# Altium schematic sheet LED v0.1.SchDoc
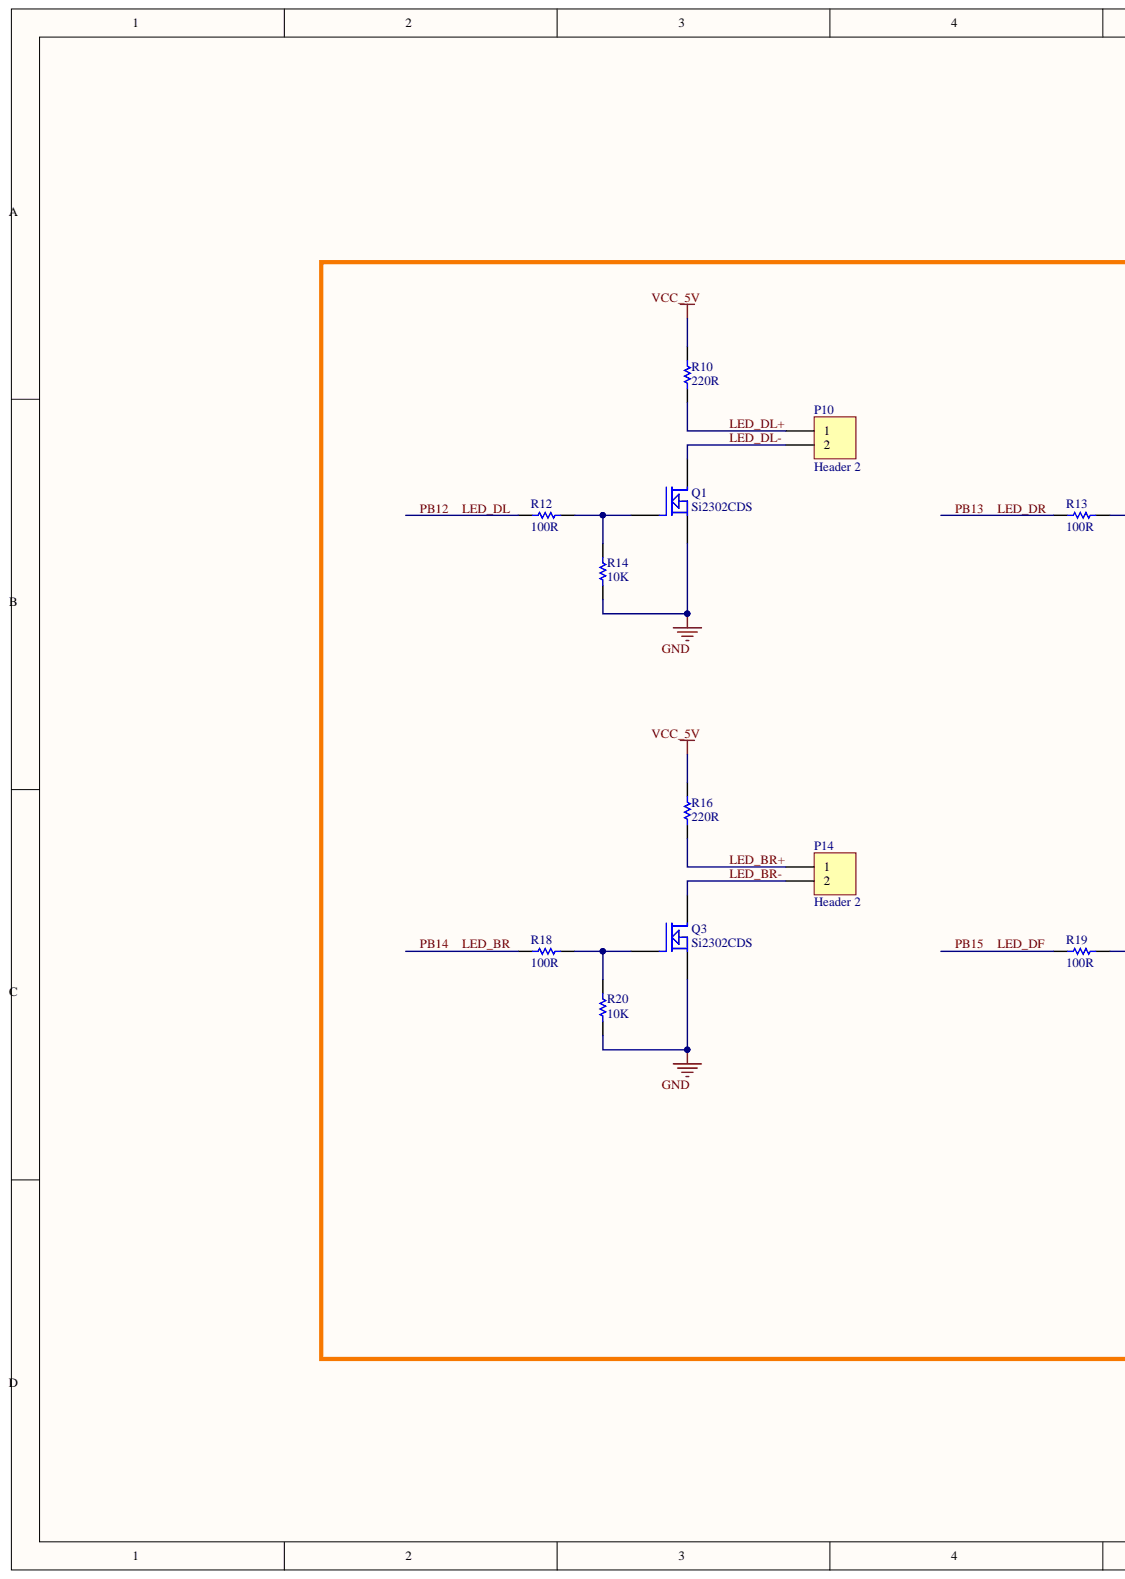
[diagram p0: LED v0.1.SchDoc, left]
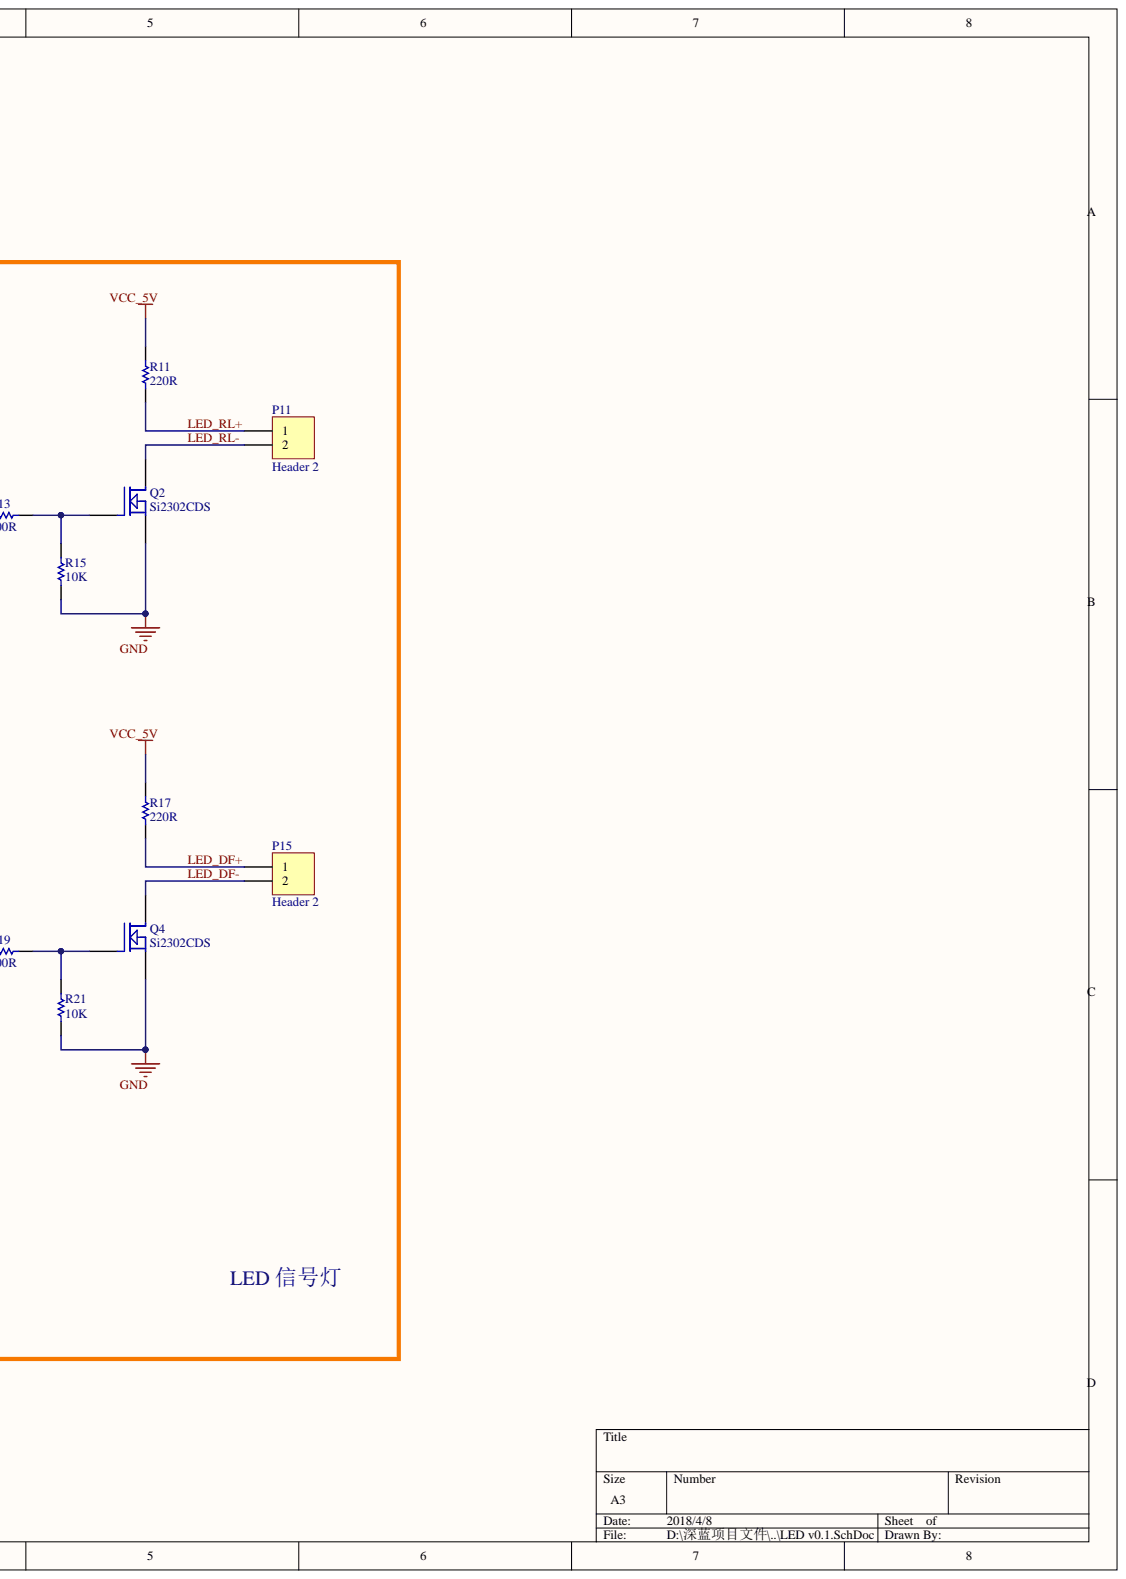
[diagram p1: LED v0.1.SchDoc, right]

## Parts
P10  Header 2  [HDR1X2]
P11  Header 2  [HDR1X2]
P14  Header 2  [HDR1X2]
P15  Header 2  [HDR1X2]
Q1  Si2302CDS  [SOT23A]
Q2  Si2302CDS  [SOT23A]
Q3  Si2302CDS  [SOT23A]
Q4  Si2302CDS  [SOT23A]
R10  value 220R  [RESC3216N]
R11  value 220R  [RESC3216N]
R12  value 100R  [RESC1608N]
R13  value 100R  [RESC1608N]
R14  value 10K  [RESC1608N]
R15  value 10K  [RESC1608N]
R16  value 220R  [RESC3216N]
R17  value 220R  [RESC3216N]
R18  value 100R  [RESC1608N]
R19  value 100R  [RESC1608N]
R20  value 10K  [RESC1608N]
R21  value 10K  [RESC1608N]

## Nets
GND: Q1.2 Q2.2 Q3.2 Q4.2 R14.1 R15.1 R20.1 R21.1
VCC_5V: R10.2 R11.2 R16.2 R17.2
LED_BR: R18.1
LED_BR+: P14.1 R16.1
LED_BR-: P14.2 Q3.3
LED_DF: R19.1
LED_DF+: P15.1 R17.1
LED_DF-: P15.2 Q4.3
LED_DL: R12.1
LED_DL+: P10.1 R10.1
LED_DL-: P10.2 Q1.3
LED_DR: R13.1
LED_RL+: P11.1 R11.1
LED_RL-: P11.2 Q2.3
NetQ1_1: Q1.1 R12.2 R14.2
NetQ2_1: Q2.1 R13.2 R15.2
NetQ3_1: Q3.1 R18.2 R20.2
NetQ4_1: Q4.1 R19.2 R21.2

## Library data of the parts
P10 P11 P14 P15 = Header 2: Header, 2-Pin; Component Kind: Standard; LatestRevisionDate: 17-Jul-2002; LatestRevisionNote: Re-released for DXP Platform.; Library Name: Miscellaneous Connectors.IntLib; Signal Integrity: Connector; Publisher: Altium Limited
Q1-Q4 = MOSFET N: Component Kind: Standard
R10-R21 = Res1: Resistor; Component Kind: Standard; LatestRevisionDate: 17-Jul-2002; LatestRevisionNote: Re-released for DXP Platform.; Library Name: Miscellaneous Devices.IntLib; PackageDescription: Axial Device, Thru-Hole; 2 Leads; 0.3 in Pin Spacing; PackageReference: AXIAL-0.3; Published: 8-Jun-2000; Signal Integrity: Res; Simulation: RESISTOR; Publisher: Altium Limited
